# Altium schematic sheet Manettino_2.SchDoc(Manettino_2)
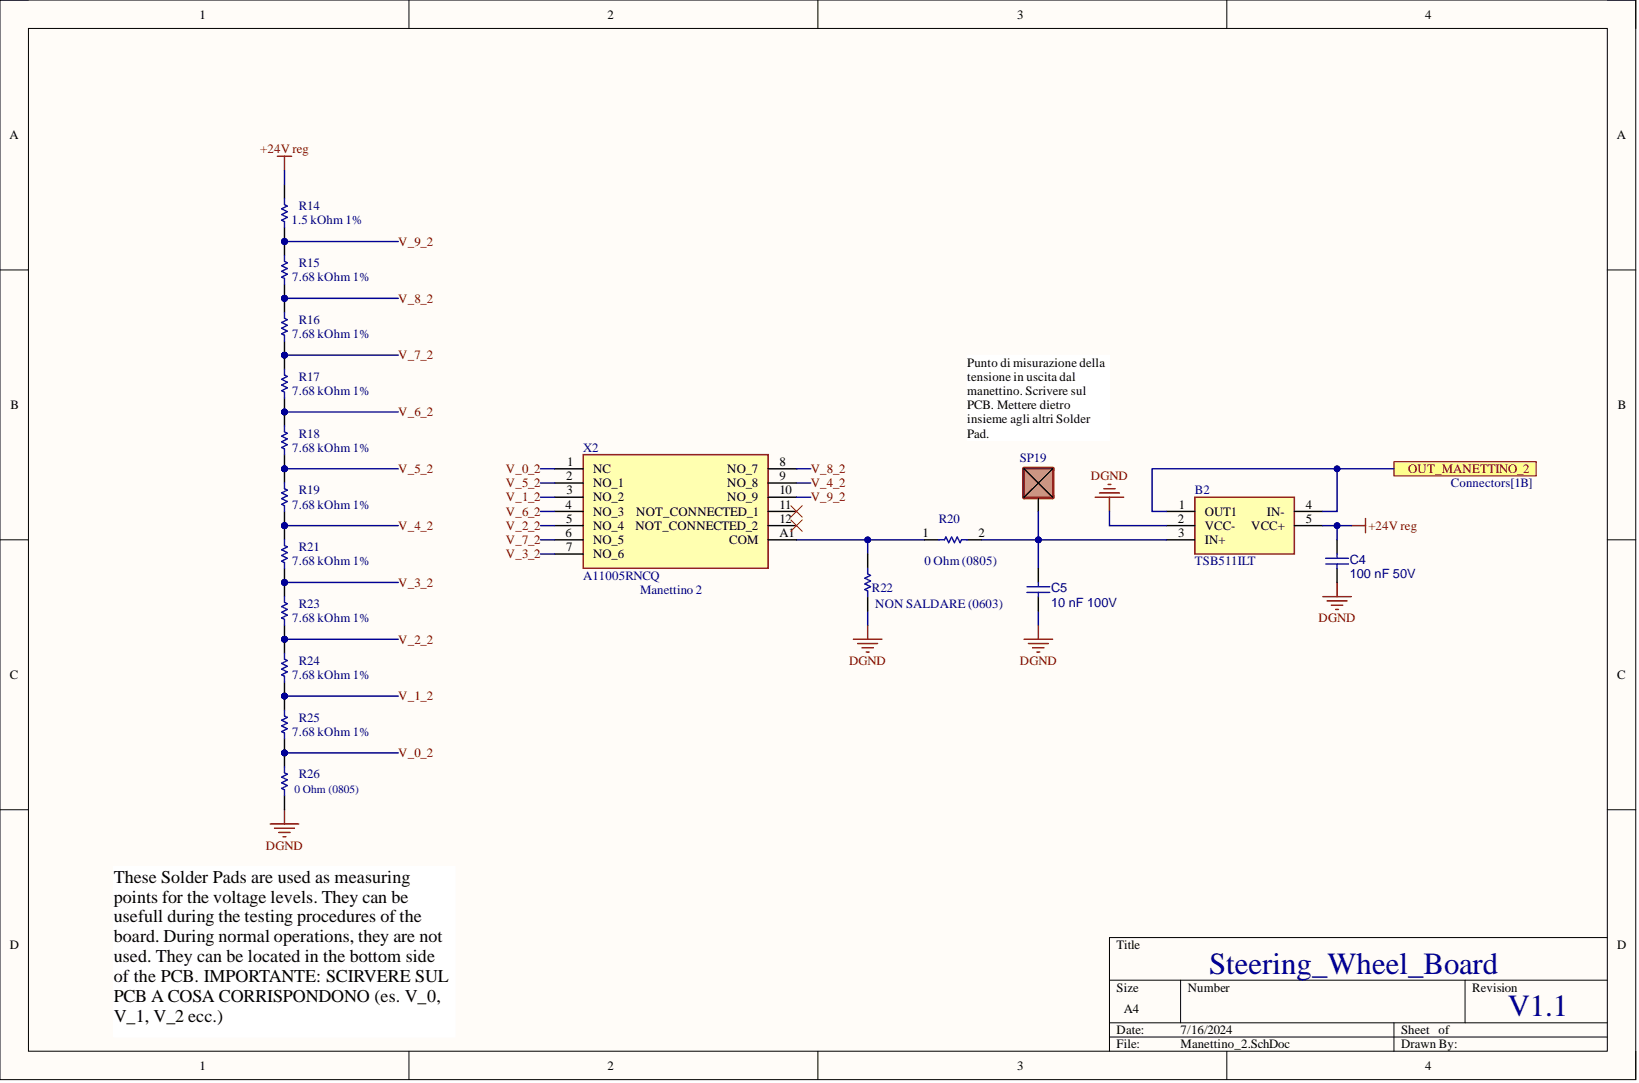
[diagram p0: Manettino_2.SchDoc]

## Parts
B2  TSB511ILT
C4  100 nF 50V
C5  10 nF 100V
R14  1.5 kOhm 1%
R15  7.68 kOhm 1%
R16  7.68 kOhm 1%
R17  7.68 kOhm 1%
R18  7.68 kOhm 1%
R19  7.68 kOhm 1%
R20  0 Ohm (0805)
R21  7.68 kOhm 1%
R22  NON SALDARE (0603)
R23  7.68 kOhm 1%
R24  7.68 kOhm 1%
R25  7.68 kOhm 1%
R26  0 Ohm (0805)
SP19  Solder_Pad_5mm  (value not drawn)
X2  A11005RNCQ

## Ports
OUT_MANETTINO_2

## Nets
+24V reg: B2.5(VCC+) C4.2 R14.2
DGND: B2.2(VCC-) C4.1 C5.1 R22.1 R26.1
OUT_MANETTINO_2: B2.1(OUT1) B2.4(IN-) ; port OUT_MANETTINO_2
V_0_2: R25.1 R26.2 X2.1(NC)
V_1_2: R24.1 R25.2 X2.3(NO_2)
V_2_2: R23.1 R24.2 X2.5(NO_4)
V_3_2: R21.1 R23.2 X2.7(NO_6)
V_4_2: R19.1 R21.2 X2.9(NO_8)
V_5_2: R18.1 R19.2 X2.2(NO_1)
V_6_2: R17.1 R18.2 X2.4(NO_3)
V_7_2: R16.1 R17.2 X2.6(NO_5)
V_8_2: R15.1 R16.2 X2.8(NO_7)
V_9_2: R14.1 R15.2 X2.10(NO_9)
NetB2_3: B2.3(IN+) C5.2 R20.2 SP19.1
NetR20_1: R20.1 R22.2 X2.A1(COM)

Not connected: X2 11(NOT_CONNECTED_1) 12(NOT_CONNECTED_2)

## Library data of the parts
B2 = CMP-002-000050-5: Rail-to-rail inputs and outputs, 36 V, 6 MHz op-amps; Manufacturer_Name: STMicroelectronics; Geometry.Height: 1.45mm; Component Kind: Standard; Datasheet Link: https://www.st.com/en/amplifiers-and-comparators/tsb511.html; Design Item ID: TSB511ILT; Manufacturer_Part_Number: TSB511ILT; Source: TSB511ILT.SchLib; Library Name: EMEA- Squadra Corse PoliTO-  Politecnico di Torino
C4 = CMP-001-00085-2: VJ0805Y104MXATW1BC; Tech: SMD; Component Kind: Standard; ComponentLink1Description: Website; ComponentLink1URL: https://www.mouser.it/ProductDetail/KYOCERA-AVX/08055C104KAT4A?qs=2ujN4bDBNE8aFT7CFyBStg%3D%3D; Package: 0805; Library Name: EMEA- Squadra Corse PoliTO-  Politecnico di Torino
C5 = CMP-001-00085-2: CC0805MRX7R9BB103; Tech: SMD; Component Kind: Standard; ComponentLink1Description: Website; ComponentLink1URL: https://www.mouser.it/ProductDetail/Vishay-Vitramon/VJ0805H103KXBBC31?qs=ak4kh1ihuH4MS2NjAUlroQ%3D%3D; Package: 0805; Library Name: EMEA- Squadra Corse PoliTO-  Politecnico di Torino
R14 = CMP-009-00179-4: RC0603FR-131K5L; Tech: SMD; Component Kind: Standard; ComponentLink1Description: Website; ComponentLink1URL: https://www.mouser.it/ProductDetail/Vishay-Dale/CRCW06031K50FKEAC?qs=E3Y5ESvWgWNew%2F2IId%252BHLA%3D%3D; Package: 0603; Library Name: EMEA- Squadra Corse PoliTO-  Politecnico di Torino
R15-R19 R21 R23-R25 = CMP-009-00179-4: RC0603FR-077K68L; Tech: SMD; Component Kind: Standard; ComponentLink1Description: Website; ComponentLink1URL: https://www.mouser.it/ProductDetail/Vishay-Dale/CRCW06037K68FKEA?qs=sGAEpiMZZMtlubZbdhIBIB%252BQki0fDm%2FcnHBRcles%2F74%3D; Package: 0603; Library Name: EMEA- Squadra Corse PoliTO-  Politecnico di Torino
R20 R26 = CMP-009-00177-13: AC0805FR-070RL; Tech: SMD; Component Kind: Standard; ComponentLink1Description: Website; Package: 0805; Library Name: EMEA- Squadra Corse PoliTO-  Politecnico di Torino
  R26: ComponentLink1URL: https://www.mouser.it/ProductDetail/YAGEO/AC0805FR-070RL?qs=ygRr%2FtkhteskusLKLf%2FBRw%3D%3D
R22 = CMP-009-00179-4: Footprint 0603; Tech: SMD; Component Kind: Standard; ComponentLink1Description: Website; Package: 0603; Library Name: EMEA- Squadra Corse PoliTO-  Politecnico di Torino
SP19 = CMP-00000-21: Solder Pad 5mm; Component Kind: Standard; Library Name: EMEA- Squadra Corse PoliTO-  Politecnico di Torino
X2 = CMP-002-000054-2: Rotary Switches Rotary; Manufacturer_Name: C & K COMPONENTS; Geometry.Height: 58.08mm; Component Kind: Standard; Datasheet Link: https://www.ckswitches.com/media/1349/arotary.pdf; Design Item ID: A11005RNCQ; Manufacturer_Part_Number: A11005RNCQ; Mouser Part Number: 611-A11005RNCQ; Mouser Price/Stock: https://www.mouser.co.uk/ProductDetail/CK/A11005RNCQ?qs=h7H1bHRqGz8p8cCPLkhHpg%3D%3D; Source: A11005RNCQ.SchLib; Library Name: EMEA- Squadra Corse PoliTO-  Politecnico di Torino
